# Altium schematic sheet MAIN.SchDoc(MAIN) (continued)

## Library data of the parts
C1 C5-C8 C10 C13 = C0603C105K8PACTU: CAP CER, 1UF, 10V, X5R, 10%, 0603; Manufacturer: KEMET; Dielectric Material: Ceramic; Case Code (Metric): 1608; Case/Package: 0603; Category: Ceramic Capacitors; Component Kind: Standard; ComponentLink1Description: https://datasheet.ciiva.com/11787/0900766b8116eb59-11787747.pdf?src-supplier=RSComponents; ComponentLink1URL: https://datasheet.ciiva.com/11787/0900766b8116eb59-11787747.pdf?src-supplier=RSComponents; ComponentLink2Description: https://datasheet.ciiva.com/6074/40889-6074647.pdf?src-supplier=Farnell; ComponentLink2URL: https://datasheet.ciiva.com/6074/40889-6074647.pdf?src-supplier=Farnell; ComponentLink3Description: https://datasheet.ciiva.com/28645/1831760-28645655.pdf?src-supplier=Element14; ComponentLink3URL: https://datasheet.ciiva.com/28645/1831760-28645655.pdf?src-supplier=Element14; ComponentLink4Description: https://datasheet.ciiva.com/26847/getdatasheetpartid-385480-26847548.pdf?src-supplier=Verical; ComponentLink4URL: https://datasheet.ciiva.com/26847/getdatasheetpartid-385480-26847548.pdf?src-supplier=Verical; ComponentLink5Description: https://datasheet.ciiva.com/7823/40889-7823998.pdf?src-supplier=Element14; ComponentLink5URL: https://datasheet.ciiva.com/7823/40889-7823998.pdf?src-supplier=Element14; ComponentLink6Description: https://datasheet.ciiva.com/7823/1929483-7823999.pdf?src-supplier=Element14; ComponentLink6URL: https://datasheet.ciiva.com/7823/1929483-7823999.pdf?src-supplier=Element14; Depth: 0.8mm; Dielectric: X5R; Height: 0.8mm; Lead Free: Lead Free; Length: 1.6mm; Library Name: Ceramic_Capacitor.SchLib; Manufacturer Part Number: C0603C105K8PACTU; Max Operating Temperature: 85°C; Min Operating Temperature: -55°C; Mount: Surface Mount; Number of Pins: 2; Package Quantity: 1; Packaging: Tape and Reel; Pricing 1: 1=0.10000, 10=0.05900, 50=0.03200, 100=0.02610, 500=0.01864, 1000=0.01464 (USD); Radiation Hardening: No; REACH SVHC: No SVHC; RoHS: Yes; Stock 1: 39312; Supplier 1: Digi-Key; Supplier Part Number 1: 399-3118-1-ND; Termination: SMD/SMT; Thickness: 0.035inch; Tolerance: 10%; Voltage: 10V; Voltage Rating: 10V; Voltage Rating (DC): 10V; Weight: 7.1E-05oz; Width: 0.031inch
  C1: Case Code (Imperial): 0603
C2 C17 = C0603C104K9RACTU: Cap Ceramic 0.1uF 6.3V X7R 10% Pad SMD 0603 125°C T/R; Manufacturer 1: KEMET; Capacitance: 100nF; Dissipation Factor: 5%; Case Code (Metric): 1608; Case/Package: 0603; Category: Ceramic Capacitors; Component Kind: Standard; ComponentLink1Description: https://datasheet.ciiva.com/13371/kem-c1002-x7r-smd-357932-13371879.pdf?src-supplier=Mouser; ComponentLink1URL: https://datasheet.ciiva.com/13371/kem-c1002-x7r-smd-357932-13371879.pdf?src-supplier=Mouser; Depth: 0.8mm; Dielectric: X7R; Dielectric Material: Ceramic; Height: 0.8mm; Length: 1.6mm; Library Name: Ceramic_Capacitor.SchLib; Manufacturer Part Number 1: C0603C104K9RACTU; Max Operating Temperature: 125°C; Min Operating Temperature: -55°C; Mount: Surface Mount; Package Quantity: 4000; Packaging: Tape and Reel; Pricing 1: 1=0,19000, 10=0,13800, 100=0,04500, 1000=0,03700, 4000=0,02700, 8000=0,02400 (USD); Radiation Hardening: No; RoHS: Yes; Stock 1: 22809; Supplier 1: Mouser; Supplier Part Number 1: 80-C0603C104K9R; Termination: SMD/SMT; Thickness: 0.034inch; Tolerance: 10%; Voltage Rating: 6.3V; Voltage Rating (DC): 6.3V; Width: 0.8mm
C3 C4 C15 C16 = C0603C103K5RACTU: Multilayer Ceramic Capacitor, C Series, 0.01 - F, - 10%, X7R, 50 V, 0603 [1608 Metric] ;RoHS Compliant: Yes; Manufacturer: KEMET; Dissipation Factor: 2.5%; Voltage: 50V; Case Code (Metric): 1608; Case/Package: 0603; Category: Ceramic Capacitors; Component Kind: Standard; ComponentLink1Description: https://datasheet.ciiva.com/7823/1732718-7823585.pdf?src-supplier=Element14; ComponentLink1URL: https://datasheet.ciiva.com/7823/1732718-7823585.pdf?src-supplier=Element14; ComponentLink2Description: https://datasheet.ciiva.com/5904/kem-c1002-x7r-smd-5904645.pdf?src-supplier=Farnell; ComponentLink2URL: https://datasheet.ciiva.com/5904/kem-c1002-x7r-smd-5904645.pdf?src-supplier=Farnell; ComponentLink3Description: https://datasheet.ciiva.com/18192/getdatasheetpartid-308803-18192662.pdf?src-supplier=Verical; ComponentLink3URL: https://datasheet.ciiva.com/18192/getdatasheetpartid-308803-18192662.pdf?src-supplier=Verical; ComponentLink4Description: https://datasheet.ciiva.com/39122/kem-c1002-x7r-smd-39122903.pdf?src-supplier=Onlinecomponents.com; ComponentLink4URL: https://datasheet.ciiva.com/39122/kem-c1002-x7r-smd-39122903.pdf?src-supplier=Onlinecomponents.com; ComponentLink5Description: https://datasheet.ciiva.com/7823/kem-c1002-x7r-smd-7823976.pdf?src-supplier=Element14; ComponentLink5URL: https://datasheet.ciiva.com/7823/kem-c1002-x7r-smd-7823976.pdf?src-supplier=Element14; ComponentLink6Description: https://datasheet.ciiva.com/7823/kem-c1002-x7r-smd-7823977.pdf?src-supplier=Element14; ComponentLink6URL: https://datasheet.ciiva.com/7823/kem-c1002-x7r-smd-7823977.pdf?src-supplier=Element14; ComponentLink7Description: https://datasheet.ciiva.com/7823/1918693-7823978.pdf?src-supplier=Element14; ComponentLink7URL: https://datasheet.ciiva.com/7823/1918693-7823978.pdf?src-supplier=Element14; ComponentLink8Description: https://datasheet.ciiva.com/14585/70095383-14585450.pdf?src-supplier=Allied+Electronics; ComponentLink8URL: https://datasheet.ciiva.com/14585/70095383-14585450.pdf?src-supplier=Allied+Electronics; ComponentLink9Description: https://datasheet.ciiva.com/18101/getdatasheetpartid-58468-18101898.pdf?src-supplier=Verical; ComponentLink9URL: https://datasheet.ciiva.com/18101/getdatasheetpartid-58468-18101898.pdf?src-supplier=Verical; ComponentLink10Description: https://datasheet.ciiva.com/5416/kem-c1002-x7r-smd-5416028.pdf?src-supplier=Mouser; ComponentLink10URL: https://datasheet.ciiva.com/5416/kem-c1002-x7r-smd-5416028.pdf?src-supplier=Mouser; ComponentLink11Description: https://datasheet.ciiva.com/28645/1851736-28645598.pdf?src-supplier=Element14; ComponentLink11URL: https://datasheet.ciiva.com/28645/1851736-28645598.pdf?src-supplier=Element14; Contact Plating: Tin,Matte; Depth: 0.8mm; Dielectric: X7R; Dielectric Material: Ceramic; Height: 0.8mm; Lead Pitch: 0.7mm; Length: 1.6mm; Library Name: Ceramic_Capacitor.SchLib; Manufacturer Part Number: C0603C103K5RACTU; Max Operating Temperature: 125°C; Min Operating Temperature: -55°C; Mount: Surface Mount,PCB; Number of Pins: 2; Package Quantity: 4000; Packaging: Tape and Reel; Pricing 1: 1=0.11000, 10=0.07700, 50=0.04220, 100=0.03440, 500=0.02456, 1000=0.01930 (USD); Radiation Hardening: No; REACH SVHC: No SVHC; RoHS: Yes; Stock 1: 2415850; Supplier 1: Digi-Key; Supplier Part Number 1: 399-1091-1-ND; Termination: SMD/SMT; Thickness: 0.034inch; Tolerance: 10%; Voltage Rating: 50V; Voltage Rating (DC): 50V; Width: 0.8mm
C9 C11 C14 = C0603C104K9RACTU: CAP CER, 0.1UF, 6.3V, X7R, 10%, 0603; Manufacturer 1: KEMET; Capacitance: 100nF; Dielectric Material: Ceramic; Dissipation Factor: 5%; Case Code (Metric): 1608; Case/Package: 0603; Category: Ceramic Capacitors; Component Kind: Standard; ComponentLink1Description: https://datasheet.ciiva.com/13371/kem-c1002-x7r-smd-357932-13371879.pdf?src-supplier=Mouser; ComponentLink1URL: https://datasheet.ciiva.com/13371/kem-c1002-x7r-smd-357932-13371879.pdf?src-supplier=Mouser; Depth: 0.8mm; Dielectric: X7R; Height: 0.8mm; Length: 1.6mm; Library Name: Ceramic_Capacitor.SchLib; Manufacturer Part Number 1: C0603C104K9RACTU; Max Operating Temperature: 125°C; Min Operating Temperature: -55°C; Mount: Surface Mount; Package Quantity: 4000; Packaging: Tape and Reel; Pricing 1: 1=0,19000, 10=0,13800, 100=0,04500, 1000=0,03700, 4000=0,02700, 8000=0,02400 (USD); Radiation Hardening: No; RoHS: Yes; Stock 1: 22809; Supplier 1: Mouser; Supplier Part Number 1: 80-C0603C104K9R; Termination: SMD/SMT; Thickness: 0.034inch; Tolerance: 10%; Voltage Rating: 6.3V; Voltage Rating (DC): 6.3V; Width: 0.8mm
  C9 C11: Case Code (Imperial): 0603
C12 = C0603C300G1HACTU: CAP CER, 22UF, 100V, X8R, 2%, 0603; Manufacturer: KEMET; Category: Ceramic Capacitors; Component Kind: Standard; Library Name: Ceramic_Capacitor.SchLib; Manufacturer Part Number: C0603C300G1HACTU; Pricing 1: 1=0.04000, 10=0.02900, 50=0.02900, 100=0.02500, 1000=0.01400, 10000=0.01200 (USD); RoHS: Yes; RoHS Compliant: Yes; Stock 1: 11458; Supplier 1: Mouser; Supplier Part Number 1: 80-C0603C300G1HACTU
C18 C19 = C0603C103K9RACTU: NM; Manufacturer 1: KEMET; Capacitance: 10nF; Dielectric: X7R; Dielectric Material: Ceramic; Dissipation Factor: 5%; Tolerance: 10%; Voltage Rating: 6.3V; Case Code (Imperial): 0603; Case Code (Metric): 1608; Case/Package: 0603; Category: Ceramic Capacitors; ComponentLink1Description: https://datasheet.ciiva.com/5421/kem-c1002-x7r-smd-357932-5421815.pdf?src-supplier=Mouser; ComponentLink1URL: https://datasheet.ciiva.com/5421/kem-c1002-x7r-smd-357932-5421815.pdf?src-supplier=Mouser; Depth: 0.8mm; Height: 0.8mm; Length: 1.6mm; Library Name: Ceramic_Capacitor.SchLib; Manufacturer Part Number 1: C0603C103K9RACTU; Max Operating Temperature: 125°C; Min Operating Temperature: -55°C; Mount: Surface Mount; Package Quantity: 1; Packaging: Tape and Reel; Pricing 1: 1=0,11000, 10=0,07600, 100=0,02400, 1000=0,02000, 4000=0,01600, 8000=0,01400 (USD); RoHS: Yes; Stock 1: 18988; Supplier 1: Mouser; Supplier Part Number 1: 80-C0603C103K9R; Termination: SMD/SMT; Thickness: 0.034inch; Voltage Rating (DC): 6.3V; Width: 0.031inch
D1 = PMEG4010CEGWX: DIODE SCHOTTKY 40V 1A 570mV SOD123; Manufacturer: Nexperia; Category: Schottky Diodes; Component Kind: Standard; ComponentLink1Description: http://www.farnell.com/datasheets/2290154.pdf?src-supplier=Farnell; ComponentLink1URL: http://www.farnell.com/datasheets/2290154.pdf?src-supplier=Farnell; ComponentLink2Description: https://assets.nexperia.com/documents/data-sheet/PMEG4010CEGW.pdf?src-supplier=Digi-Key; ComponentLink2URL: https://assets.nexperia.com/documents/data-sheet/PMEG4010CEGW.pdf?src-supplier=Digi-Key; ComponentLink3Description: http://www.farnell.com/datasheets/2290154.pdf?src-supplier=Element14; ComponentLink3URL: http://www.farnell.com/datasheets/2290154.pdf?src-supplier=Element14; ComponentLink4Description: http://www.farnell.com/datasheets/2290154.pdf?src-supplier=Newark; ComponentLink4URL: http://www.farnell.com/datasheets/2290154.pdf?src-supplier=Newark; Library Name: schottky.SchLib; Manufacturer Part Number: PMEG4010CEGWX; Pricing 1: 1=0.29000, 10=0.19400, 50=0.19400, 100=0.09100, 1000=0.05400, 10000=0.03500 (USD); RoHS: No; RoHS Compliant: No; Stock 1: 3187; Supplier 1: Mouser; Supplier Part Number 1: 841-PMEG4010CEGWX
D2 D3 = ESD5Z7.0T1G: TVS Diodes / ESD Suppressors SOD523 ESD DIODE; Manufacturer: ON Semiconductor; Breakdown Voltage: 7.5V; Capacitance: 65pF; Clamping Voltage: 22.7V; Diode Type: ZENER; Direction: Unidirectional; Element Configuration: Single; ESD Protection: Yes; Leakage Current: 10nA; Max Power Dissipation: 240W; Max Surge Current: 8.8A; Number of Channels: 1; Operating Supply Voltage: 7V; Peak Pulse Power: 200W; Power Line Protection: No; Test Current: 1mA; Case/Package: SOD-523-2; Category: ESD and Circuit Protection ICs; Clamping Voltage-Max: 22.7V; Component Kind: Standard; ComponentLink1Description: https://datasheet.ciiva.com/353/esd5z2-5t1-d-353760.pdf?src-supplier=Digi-Key; ComponentLink1URL: https://datasheet.ciiva.com/353/esd5z2-5t1-d-353760.pdf?src-supplier=Digi-Key; ComponentLink2Description: https://datasheet.ciiva.com/30478/0900766b8125092b-30478674.pdf?src-supplier=RSComponents; ComponentLink2URL: https://datasheet.ciiva.com/30478/0900766b8125092b-30478674.pdf?src-supplier=RSComponents; ComponentLink3Description: https://datasheet.ciiva.com/353/esd5z2-5t1-d-353761.pdf?src-supplier=Digi-Key; ComponentLink3URL: https://datasheet.ciiva.com/353/esd5z2-5t1-d-353761.pdf?src-supplier=Digi-Key; ComponentLink4Description: https://datasheet.ciiva.com/11195/esd5z2-5t1-d-11195333.pdf?src-supplier=Avnet; ComponentLink4URL: https://datasheet.ciiva.com/11195/esd5z2-5t1-d-11195333.pdf?src-supplier=Avnet; ComponentLink5Description: https://datasheet.ciiva.com/16437/esd5z2-5t1-d-16437829.pdf?src-supplier=Future+Electronics; ComponentLink5URL: https://datasheet.ciiva.com/16437/esd5z2-5t1-d-16437829.pdf?src-supplier=Future+Electronics; ComponentLink6Description: https://datasheet.ciiva.com/7973/esd5z2-5t1-d-7973851.pdf?src-supplier=Element14; ComponentLink6URL: https://datasheet.ciiva.com/7973/esd5z2-5t1-d-7973851.pdf?src-supplier=Element14; ComponentLink7Description: https://datasheet.ciiva.com/26817/getdatasheetpartid-120991-26817819.pdf?src-supplier=Verical; ComponentLink7URL: https://datasheet.ciiva.com/26817/getdatasheetpartid-120991-26817819.pdf?src-supplier=Verical; ComponentLink8Description: https://datasheet.ciiva.com/353/esd5z2-5t1-d-353759.pdf?src-supplier=Digi-Key; ComponentLink8URL: https://datasheet.ciiva.com/353/esd5z2-5t1-d-353759.pdf?src-supplier=Digi-Key; Contact Plating: Tin,Matte; Depth: 0.8mm; Halogen Free: Halogen Free; Height: 0.7mm; Lead Free: Lead Free; Length: 1.2mm; Library Name: ESD.SchLib; Manufacturer Part Number: ESD5Z7.0T1G; Max Breakdown Voltage: 7.5V; Max Operating Temperature: 150°C; Max Reverse Leakage Current: 10nA; Min Breakdown Voltage: 7.5V; Min Operating Temperature: -55°C; Number of Elements: 1; Number of Lines: 1; Number of Pins: 2; Number of Unidirectional Channels: 1; Package Quantity: 1; Packaging: Tape and Reel; Peak Pulse Current: 8.8A; Polarity: Unidirectional; Pricing 1: 1=0.17000, 10=0.11700, 50=0.11700, 100=0.05000, 1000=0.03500, 10000=0.02300 (USD); Radiation Hardening: No; REACH SVHC: No SVHC; Resistor Type: Zener; Reverse Breakdown Voltage: 7.5V; Reverse Standoff Voltage: 7V; RoHS: Yes; RoHS Compliant: Yes; Series: Automotive, AEC-Q101; Stock 1: 23017; Supplier 1: Mouser; Supplier Part Number 1: 863-ESD5Z7.0T1G; Termination: SMD/SMT; Width: 0.9mm; Working Voltage: 7V
D4 = KPG1-1608SURC-TT: Led, Red, 65MCD, 631NM, SMD, 1608; Manufacturer: Kingbright; Component Kind: Standard; ComponentLink1Description: http://www.farnell.com/datasheets/2163487.pdf?src-supplier=Farnell; ComponentLink1URL: http://www.farnell.com/datasheets/2163487.pdf?src-supplier=Farnell; Library Name: LED.SchLib; Manufacturer Part Number: KPG1-1608SURC-TT; Pricing 1: 25=0.16500 (USD); RoHS: No; Stock 1: 2675; Supplier 1: RSComponents; Supplier Part Number 1: 1254508
F1 = 0ZCK0075FF2E: BEL FUSE - 0ZCK0075FF2E - FUSE, RESETTABLE PTC, 6VDC, 0.75A, SMD; Hold Current: 750mA; Max Current Rating: 40A; Time to Trip: 0.2s; Trip Current: 1.5A; Case Code (Imperial): 0805; Case Code (Metric): 2012; Case/Package: 0805; Category: PTC Resettable Fuses; Component Kind: Standard; ComponentLink1Description: https://datasheet.ciiva.com/18550/0zck-18550716.pdf?src-supplier=Digi-Key; ComponentLink1URL: https://datasheet.ciiva.com/18550/0zck-18550716.pdf?src-supplier=Digi-Key; ComponentLink2Description: https://datasheet.ciiva.com/18550/0zck-18550717.pdf?src-supplier=Digi-Key; ComponentLink2URL: https://datasheet.ciiva.com/18550/0zck-18550717.pdf?src-supplier=Digi-Key; Lead Free: Lead Free; Manufacturer: Bel; Manufacturer Part Number: 0ZCK0075FF2E; Package Quantity: 1; Packaging: Tape and Reel; Pricing 1: 1=0.17000, 10=0.17000, 50=0.12300, 100=0.10900, 1000=0.08600, 10000=0.07300 (USD); RoHS: Yes; RoHS Compliant: Yes; Stock 1: 8730; Supplier 1: Mouser; Supplier Part Number 1: 530-0ZCK0075FF2E; Library Name: PPTC_Fuses.SchLib
F2 = Helical_868-915MHZ: 19x11mm Helical antenna. Requieres load matching  (impedance at 868MHz: 10-88j, VSWR 22); Library Name: antenna_pcb.SchLib
L1 = CVH252009-2R2M: 2.2uH, 1.3A , 20%, 1MHz; Manufacturer: Bourns; Core Material: Ferrite; DC Resistance (DCR): 80mR; Self Resonant Frequency: 40MHz; Shielding: Shielded; Case Code (Metric): 2520; Case/Package: 1008; Category: Fixed Inductors; Component Kind: Standard; ComponentLink1Description: https://datasheet.ciiva.com/6703/cvh25200920series-6703456.pdf?src-supplier=Digi-Key; ComponentLink1URL: https://datasheet.ciiva.com/6703/cvh25200920series-6703456.pdf?src-supplier=Digi-Key; ComponentLink2Description: https://datasheet.ciiva.com/3079/cvh-3079746.pdf?src-supplier=Avnet; ComponentLink2URL: https://datasheet.ciiva.com/3079/cvh-3079746.pdf?src-supplier=Avnet; ComponentLink3Description: https://datasheet.ciiva.com/7849/1610598-7849317.pdf?src-supplier=Element14; ComponentLink3URL: https://datasheet.ciiva.com/7849/1610598-7849317.pdf?src-supplier=Element14; ComponentLink4Description: https://datasheet.ciiva.com/22874/70301233-22874395.pdf?src-supplier=Allied+Electronics; ComponentLink4URL: https://datasheet.ciiva.com/22874/70301233-22874395.pdf?src-supplier=Allied+Electronics; ComponentLink5Description: https://datasheet.ciiva.com/6703/cvh252009series-6703455.pdf?src-supplier=Digi-Key; ComponentLink5URL: https://datasheet.ciiva.com/6703/cvh252009series-6703455.pdf?src-supplier=Digi-Key; Contact Plating: Tin; Current Rating: 1.3A; DC Current: 1.3A; Depth: 2.2mm; Height - Seated (Max): 0.039inch; Inductance Tolerance: 20%; Length: 0.098inch; Library Name: Fixed_inductors.SchLib; Manufacturer Part Number: CVH252009-2R2M; Max DC Current: 1.3A; Max Operating Temperature: 125°C; Military Standard: Not; Min Operating Temperature: -55°C; Mount: Surface Mount; Package Quantity: 3000; Packaging: Tape and Reel; Pricing 1: 1=0.23000, 10=0.18800, 50=0.16900, 100=0.15800, 1000=0.11100, 10000=0.08000 (USD); REACH SVHC: No SVHC; RoHS: Yes; RoHS Compliant: Yes; Series: CVH252009; Stock 1: 41990; Supplier 1: Mouser; Supplier Part Number 1: 652-CVH252009-2R2M; Termination: SMD/SMT; Test Frequency: 1MHz; Tolerance: 20%; Width: 0.079inch
L2 = 2506031217Z0: NM; Brand: Fair-Rite; Impedance: 120 Ohms; Maximum DC Current: 450 mA; Maximum DC Resistance: 300 mOhms; Test Frequency: 100 MHz; Tolerance: 25 %; Type: Multilayer Ferrite Chip Bead; Capacitance: -; Category: Ferrite Beads; ComponentLink1Description: https://www.mouser.com/datasheet/2/150/2506031217Z0-471794.pdf; ComponentLink1URL: https://www.mouser.com/datasheet/2/150/2506031217Z0-471794.pdf; Height: 0.8 mm; Length: 1.6 mm; Library Name: Ferrite.SchLib; Manufacturer: Fair-Rite; Manufacturer Part Number: 2506031217Z0; Maximum Operating Temperature: + 125 C; Minimum Operating Temperature: - 55 C; Minimum Order Quantity: 1; Operating Temperature Range: - 55 C to + 125 C; Package / Case: 0603 (1608 metric); Packaging: Cut Tape; Pricing 1: 1=0.032, 2500=0.027, 4000=0.025, 8000=0.024, 24000=0.022, 48000=0.021, 100000=0.018, 400000=0.016 (EUR); Product: Ferrite Chip Beads; Product Type: Ferrite Beads; Reeling: True; RoHS: RoHS Compliant; RoHS - Mouser: Y; Standard Pack Qty: 4000; Stock 1: 9619; Subcategory: Ferrites; Supplier 1: Mouser; Supplier Part Number 1: 623-2506031217Z0; Termination: -; Termination Style: SMD/SMT; Width: 0.8 mm
logo1 = Robolabo: Library Name: logo.SchLib
P1 P4 = CONN-2X15-1.27-MALE-RECT: Description: CONN-2X15-1.27-MALE-RECT; Library Name: pin.SchLib
P2 = S2B-PH-SM4-TB: CONN MALE RECT 2 POS 2mm; Component Kind: Standard; Library Name: PCB_CONNECTOR.SchLib
P3 = CONN-1X2-2.54-PCB: Library Name: pin.SchLib
P5 = CONN-2X5-1.27-MALE-RECT: Description: CONN-2X5-1.27-MALE-RECT; Library Name: pin.SchLib
P6 = 73412-0110: MOLEX   73412-0110   RF COAXIAL, MICROCOAXIAL, JACK, 50OHM; Body Material: Polymer,Liquid Crystal Polymer; Body Plating: Gold; Frequency: 6GHz; Gender: Female; Impedance: 50R; Insulation Resistance: 500MR; Mating Cycles: 30; Polarity: Standard; Voltage Rating: 60V; Category: RF / Coaxial Connectors; Component Kind: Standard; ComponentLink1Description: https://datasheet.ciiva.com/30219/250360734120110-rf-coax-nectors-30219809.pdf?src-supplier=Onlinecomponents.com; ComponentLink1URL: https://datasheet.ciiva.com/30219/250360734120110-rf-coax-nectors-30219809.pdf?src-supplier=Onlinecomponents.com; ComponentLink2Description: https://datasheet.ciiva.com/16653/0734120110-rf-coax-connectors-16653186.pdf?src-supplier=Avnet+Europe; ComponentLink2URL: https://datasheet.ciiva.com/16653/0734120110-rf-coax-connectors-16653186.pdf?src-supplier=Avnet+Europe; ComponentLink3Description: https://datasheet.ciiva.com/11762/0900766b80dfdeb4-11762356.pdf?src-supplier=RSComponents; ComponentLink3URL: https://datasheet.ciiva.com/11762/0900766b80dfdeb4-11762356.pdf?src-supplier=RSComponents; ComponentLink4Description: https://datasheet.ciiva.com/22773/1841262-22773140.pdf?src-supplier=Farnell; ComponentLink4URL: https://datasheet.ciiva.com/22773/1841262-22773140.pdf?src-supplier=Farnell; ComponentLink5Description: https://datasheet.ciiva.com/4600/0734120110-rf-coax-connectors-173239-4600647.pdf?src-supplier=Mouser; ComponentLink5URL: https://datasheet.ciiva.com/4600/0734120110-rf-coax-connectors-173239-4600647.pdf?src-supplier=Mouser; ComponentLink6Description: https://datasheet.ciiva.com/16081/1387750734120110-rf-coax-nectors-16081145.pdf?src-supplier=Onlinecomponents.com; ComponentLink6URL: https://datasheet.ciiva.com/16081/1387750734120110-rf-coax-nectors-16081145.pdf?src-supplier=Onlinecomponents.com; ComponentLink7Description: https://datasheet.ciiva.com/46384/1808577-46384459.pdf?src-supplier=Element14; ComponentLink7URL: https://datasheet.ciiva.com/46384/1808577-46384459.pdf?src-supplier=Element14; ComponentLink8Description: https://datasheet.ciiva.com/9419/0734120110-rf-coax-connectors-9419357.pdf?src-supplier=Avnet; ComponentLink8URL: https://datasheet.ciiva.com/9419/0734120110-rf-coax-connectors-9419357.pdf?src-supplier=Avnet; Connector Type: Coaxial; Contact Material: Bronze,Brass; Contact Plating: Gold; Halogen Free: Low Halogen; Lead Free: Lead Free; Library Name: RF_Connectors.SchLib; Manufacturer: Molex; Manufacturer Part Number: 73412-0110; Material: Bronze; Max Frequency: 6GHz; Max Operating Temperature: 90°C; Min Operating Temperature: -40°C; Mount: Surface Mount,Through Hole,PCB; Orientation: Straight; Package Quantity: 5000; Packaging: Tape and Reel; Pricing 1: 1=0.77000, 10=0.72200, 25=0.64960, 50=0.57740, 100=0.55330, 250=0.50520, 500=0.48114, 1000=0.39694, 2500=0.36086 (USD); Radiation Hardening: No; REACH SVHC: Unknown; RoHS: Yes; Stock 1: 98770; Supplier 1: Digi-Key; Supplier Part Number 1: WM5587CT-ND; Termination: Solder; Voltage Rating (AC): 60V
Q1 = SI2369DS-T1-GE3: VISHAY - SI2369DS-T1-GE3 - MOSFET, P-CH, -30V, -7.6A, 150DEG C; Manufacturer: Vishay Siliconix; Drain to Source Breakdown Voltage: 30V; Drain to Source Resistance: 29mR; Gate to Source Voltage (Vgs): 20V; Input Capacitance: 1.295nF; Max Power Dissipation: 2.5W; Number of Channels: 1; Case/Package: TO-236-3; Category: MOSFETs; Component Kind: Standard; ComponentLink1Description: https://datasheet.ciiva.com/29747/si2369d-29747874.pdf?src-supplier=Digi-Key; ComponentLink1URL: https://datasheet.ciiva.com/29747/si2369d-29747874.pdf?src-supplier=Digi-Key; ComponentLink2Description: https://datasheet.ciiva.com/29747/si2369d-29747879.pdf?src-supplier=Digi-Key; ComponentLink2URL: https://datasheet.ciiva.com/29747/si2369d-29747879.pdf?src-supplier=Digi-Key; Contact Plating: Tin,Matte; Continuous Drain Current (ID): -7.6A; Drain to Source Voltage (Vdss): 30V; Library Name: MOSFET.SchLib; Manufacturer Part Number: SI2369DS-T1-GE3; Max Operating Temperature: 150°C; Min Operating Temperature: -55°C; Mount: Surface Mount; Number of Pins: 3; Package Quantity: 3000; Packaging: Tape and Reel; Pricing 1: 1=0.36000, 10=0.32600, 100=0.24200, 500=0.19900, 1000=0.15300, 3000=0.14000 (USD); Radiation Hardening: No; Rds On Max: 29mR; REACH SVHC: No SVHC; RoHS: Yes; Stock 1: 37245; Supplier 1: Mouser; Supplier Part Number 1: 78-SI2369DS-T1-GE3
R1 R2 R5 = CRCW0603100KFKEAHP: RES SMD 100K OHM 1% 1/10W 0603; Manufacturer: Vishay; Max Power Dissipation: 250mW; Resistance: 100kR; Temperature Coefficient: 100ppm/°C; Voltage Rating: 75V; Case Code (Metric): 1608; Case/Package: 0603; Category: Chip SMD Resistors; Component Kind: Standard; ComponentLink1Description: https://datasheet.ciiva.com/3256/crcwhpe3-3256918.pdf?src-supplier=Avnet; ComponentLink1URL: https://datasheet.ciiva.com/3256/crcwhpe3-3256918.pdf?src-supplier=Avnet; ComponentLink2Description: https://datasheet.ciiva.com/2472/crcwhpe3-2472535.pdf?src-supplier=Digi-Key; ComponentLink2URL: https://datasheet.ciiva.com/2472/crcwhpe3-2472535.pdf?src-supplier=Digi-Key; ComponentLink3Description: https://datasheet.ciiva.com/2472/crcwhpe3-2472536.pdf?src-supplier=Digi-Key; ComponentLink3URL: https://datasheet.ciiva.com/2472/crcwhpe3-2472536.pdf?src-supplier=Digi-Key; ComponentLink4Description: https://datasheet.ciiva.com/16211/crcwhpe3-16211869.pdf?src-supplier=Future+Electronics; ComponentLink4URL: https://datasheet.ciiva.com/16211/crcwhpe3-16211869.pdf?src-supplier=Future+Electronics; ComponentLink5Description: https://datasheet.ciiva.com/7777/424479-7777270.pdf?src-supplier=Element14; ComponentLink5URL: https://datasheet.ciiva.com/7777/424479-7777270.pdf?src-supplier=Element14; ComponentLink6Description: https://datasheet.ciiva.com/7777/424479-7777271.pdf?src-supplier=Element14; ComponentLink6URL: https://datasheet.ciiva.com/7777/424479-7777271.pdf?src-supplier=Element14; ComponentLink7Description: https://datasheet.ciiva.com/2472/crcwhpe3-2472537.pdf?src-supplier=Digi-Key; ComponentLink7URL: https://datasheet.ciiva.com/2472/crcwhpe3-2472537.pdf?src-supplier=Digi-Key; Composition: Thick Film; Contact Plating: Tin; Depth: 0.85mm; Features: Automotive AEC-Q200; Height: 0.45mm; Lead Free: Lead Free; Length: 1.6mm; Library Name: Resistor.SchLib; Manufacturer Part Number: CRCW0603100KFKEAHP; Max Operating Temperature: 155°C; Military Standard: Not; Min Operating Temperature: -55°C; Number of Terminations: 2; Package Quantity: 10; Packaging: Tape and Reel; Power Rating: 250mW; Pricing 1: 1=0.16000, 10=0.13600, 100=0.05330, 1000=0.02232, 2500=0.02046 (USD); Radiation Hardening: No; REACH SVHC: Unknown; RoHS: Yes; Stock 1: 8564; Supplier 1: Digi-Key; Supplier Part Number 1: 541-100KSCT-ND; Termination: SMD/SMT; Tolerance: 1%; Voltage Rating (DC): 75V; Weight: 7.1E-05oz; Width: 0.8mm
  R2 R5: Case Code (Imperial): 0603
R3 R8 R10 = CRCW0603-0000Z0EA: RES SMD 0 OHM 0603; Manufacturer 1: Vishay; Current Rating: 2A; Max Power Dissipation: 100mW; Resistance: 0mR; Temperature Coefficient: 100ppm/°C; Tolerance: 5%; Voltage Rating: 75V; Case Code (Metric): 1608; Case/Package: 0603; Component Kind: Standard; ComponentLink1Description: https://datasheet.ciiva.com/2461/dcrcwe3-2461968.pdf?src-supplier=Digi-Key; ComponentLink1URL: https://datasheet.ciiva.com/2461/dcrcwe3-2461968.pdf?src-supplier=Digi-Key; ComponentLink2Description: https://datasheet.ciiva.com/2461/dcrcwe3-2461969.pdf?src-supplier=Digi-Key; ComponentLink2URL: https://datasheet.ciiva.com/2461/dcrcwe3-2461969.pdf?src-supplier=Digi-Key; ComponentLink3Description: https://datasheet.ciiva.com/2461/dcrcwe3-2461970.pdf?src-supplier=Digi-Key; ComponentLink3URL: https://datasheet.ciiva.com/2461/dcrcwe3-2461970.pdf?src-supplier=Digi-Key; ComponentLink4Description: https://datasheet.ciiva.com/8340/dcrcwe3-8340197.pdf?src-supplier=Avnet; ComponentLink4URL: https://datasheet.ciiva.com/8340/dcrcwe3-8340197.pdf?src-supplier=Avnet; ComponentLink5Description: https://datasheet.ciiva.com/16941/dcrcw-16941302.pdf?src-supplier=Avnet+Europe; ComponentLink5URL: https://datasheet.ciiva.com/16941/dcrcw-16941302.pdf?src-supplier=Avnet+Europe; ComponentLink6Description: https://datasheet.ciiva.com/16199/dcrcwe3-16199063.pdf?src-supplier=Future+Electronics; ComponentLink6URL: https://datasheet.ciiva.com/16199/dcrcwe3-16199063.pdf?src-supplier=Future+Electronics; ComponentLink7Description: https://datasheet.ciiva.com/16725/70201119-16725175.pdf?src-supplier=Allied+Electronics; ComponentLink7URL: https://datasheet.ciiva.com/16725/70201119-16725175.pdf?src-supplier=Allied+Electronics; ComponentLink8Description: https://datasheet.ciiva.com/26316/fld-crcw-e3-data-en-26316615.pdf?src-supplier=Distrelec; ComponentLink8URL: https://datasheet.ciiva.com/26316/fld-crcw-e3-data-en-26316615.pdf?src-supplier=Distrelec; ComponentLink9Description: https://datasheet.ciiva.com/5544/dcrcwe3-109170-5544344.pdf?src-supplier=Mouser; ComponentLink9URL: https://datasheet.ciiva.com/5544/dcrcwe3-109170-5544344.pdf?src-supplier=Mouser; ComponentLink10Description: https://datasheet.ciiva.com/7841/1720486-7841816.pdf?src-supplier=Element14; ComponentLink10URL: https://datasheet.ciiva.com/7841/1720486-7841816.pdf?src-supplier=Element14; ComponentLink11Description: https://datasheet.ciiva.com/7841/1720486-7841817.pdf?src-supplier=Element14; ComponentLink11URL: https://datasheet.ciiva.com/7841/1720486-7841817.pdf?src-supplier=Element14; ComponentLink12Description: https://datasheet.ciiva.com/7841/125364-7841818.pdf?src-supplier=Element14; ComponentLink12URL: https://datasheet.ciiva.com/7841/125364-7841818.pdf?src-supplier=Element14; ComponentLink13Description: https://datasheet.ciiva.com/16721/70201119-16721450.pdf?src-supplier=Allied+Electronics; ComponentLink13URL: https://datasheet.ciiva.com/16721/70201119-16721450.pdf?src-supplier=Allied+Electronics; Composition: Thick Film; Contact Plating: Tin,Lead; Depth: 0.85mm; Features: Automotive AEC-Q200; Height: 0.02inch; Lead Free: Lead Free; Length: 1.55mm; Library Name: Resistor.SchLib; Manufacturer Part Number 1: CRCW0603-0000Z0EA; Max Operating Temperature: 155°C; Military Standard: Not; Min Operating Temperature: -55°C; Mount: Surface Mount; Number of Terminations: 2; Package Quantity: 1; Packaging: Tape and Reel; Power Rating: 100mW; Pricing 1: 1=0,100000, 10=0,017000, 100=0,012000, 500=0,007000, 1000=0,006000, 2500=0,005000, 5000=0,004000, 10000=0,003000 (USD); Radiation Hardening: No; REACH SVHC: Unknown; RoHS: Yes; Stock 1: 1187486; Supplier 1: Mouser; Supplier Part Number 1: 71-CRCW0603-0-E3; Termination: SMD/SMT; Voltage Rating (DC): 75V; Weight: 7.1E-05oz; Width: 0.033inch; Working Voltage: 75V
R4 = CRCW060310K0FKEA: RES SMD 10K OHM 1% 1/10W 0603; Manufacturer: Vishay; Max Power Dissipation: 100mW; Resistance: 10kR; Temperature Coefficient: 100ppm/°C; Voltage Rating: 75V; Case Code (Metric): 1608; Case/Package: 0603; Category: Chip SMD Resistors; Component Kind: Standard; ComponentLink1Description: https://datasheet.ciiva.com/11809/0900766b80d98afc-11809037.pdf?src-supplier=RSComponents; ComponentLink1URL: https://datasheet.ciiva.com/11809/0900766b80d98afc-11809037.pdf?src-supplier=RSComponents; ComponentLink2Description: https://datasheet.ciiva.com/16886/dcrcw-16886037.pdf?src-supplier=Avnet+Europe; ComponentLink2URL: https://datasheet.ciiva.com/16886/dcrcw-16886037.pdf?src-supplier=Avnet+Europe; ComponentLink3Description: https://datasheet.ciiva.com/26821/getdatasheetpartid-86831-26821109.pdf?src-supplier=Verical; ComponentLink3URL: https://datasheet.ciiva.com/26821/getdatasheetpartid-86831-26821109.pdf?src-supplier=Verical; ComponentLink4Description: https://datasheet.ciiva.com/16754/70201119-16754710.pdf?src-supplier=Allied+Electronics; ComponentLink4URL: https://datasheet.ciiva.com/16754/70201119-16754710.pdf?src-supplier=Allied+Electronics; ComponentLink5Description: https://datasheet.ciiva.com/16719/70201119-16719704.pdf?src-supplier=Allied+Electronics; ComponentLink5URL: https://datasheet.ciiva.com/16719/70201119-16719704.pdf?src-supplier=Allied+Electronics; ComponentLink6Description: https://datasheet.ciiva.com/2465/dcrcwe3-2465513.pdf?src-supplier=Digi-Key; ComponentLink6URL: https://datasheet.ciiva.com/2465/dcrcwe3-2465513.pdf?src-supplier=Digi-Key; ComponentLink7Description: https://datasheet.ciiva.com/5543/dcrcwe3-109170-5543001.pdf?src-supplier=Mouser; ComponentLink7URL: https://datasheet.ciiva.com/5543/dcrcwe3-109170-5543001.pdf?src-supplier=Mouser; ComponentLink8Description: https://datasheet.ciiva.com/2465/dcrcwe3-2465514.pdf?src-supplier=Digi-Key; ComponentLink8URL: https://datasheet.ciiva.com/2465/dcrcwe3-2465514.pdf?src-supplier=Digi-Key; ComponentLink9Description: https://datasheet.ciiva.com/46385/1697337-46385498.pdf?src-supplier=Element14; ComponentLink9URL: https://datasheet.ciiva.com/46385/1697337-46385498.pdf?src-supplier=Element14; ComponentLink10Description: https://datasheet.ciiva.com/2465/dcrcwe3-2465515.pdf?src-supplier=Digi-Key; ComponentLink10URL: https://datasheet.ciiva.com/2465/dcrcwe3-2465515.pdf?src-supplier=Digi-Key; ComponentLink11Description: https://datasheet.ciiva.com/3256/dcrcwe3-3256939.pdf?src-supplier=Avnet; ComponentLink11URL: https://datasheet.ciiva.com/3256/dcrcwe3-3256939.pdf?src-supplier=Avnet; ComponentLink12Description: https://datasheet.ciiva.com/3256/dcrcwe3-3256940.pdf?src-supplier=Avnet; ComponentLink12URL: https://datasheet.ciiva.com/3256/dcrcwe3-3256940.pdf?src-supplier=Avnet; ComponentLink13Description: https://datasheet.ciiva.com/16209/dcrcwe3-16209164.pdf?src-supplier=Future+Electronics; ComponentLink13URL: https://datasheet.ciiva.com/16209/dcrcwe3-16209164.pdf?src-supplier=Future+Electronics; ComponentLink14Description: https://datasheet.ciiva.com/26314/fld-crcw-e3-data-en-26314749.pdf?src-supplier=Distrelec; ComponentLink14URL: https://datasheet.ciiva.com/26314/fld-crcw-e3-data-en-26314749.pdf?src-supplier=Distrelec; Composition: Thick Film; Contact Plating: Tin,Lead; Depth: 0.85mm; Features: Automotive AEC-Q200; Height: 0.45mm; Lead Free: Lead Free; Length: 1.55mm; Library Name: Resistor.SchLib; Manufacturer Part Number: CRCW060310K0FKEA; Max Operating Temperature: 155°C; Military Standard: Not; Min Operating Temperature: -55°C; Number of Pins: 2; Number of Terminations: 2; Package Quantity: 10; Packaging: Tape and Reel; Power Rating: 100mW; Pricing 1: 1=0.10000, 10=0.03300, 100=0.01340, 1000=0.00602, 2500=0.00522 (USD); Radiation Hardening: No; REACH SVHC: Unknown; RoHS: Yes; Stock 1: 633553; Supplier 1: Digi-Key; Supplier Part Number 1: 541-10.0KHCT-ND; Termination: SMD/SMT; Voltage Rating (DC): 75V; Weight: 7.1E-05oz; Width: 0.033inch
R6 R7 = CRCW06034K70FKTA: RES SMD 4.7K OHM 1% 1/10W 0603; Manufacturer 1: Vishay Dale; Max Power Dissipation: 100mW; Resistance: 4.7kR; Temperature Coefficient: 100ppm/°C; Voltage Rating: 75V; Case Code (Metric): 1608; Case/Package: 0603; Category: Chip SMD Resistors; Component Kind: Standard; ComponentLink1Description: https://datasheet.ciiva.com/2565/dcrcw-2565426.pdf?src-supplier=Digi-Key; ComponentLink1URL: https://datasheet.ciiva.com/2565/dcrcw-2565426.pdf?src-supplier=Digi-Key; ComponentLink2Description: https://datasheet.ciiva.com/9071/dcrcw-9071351.pdf?src-supplier=Avnet; ComponentLink2URL: https://datasheet.ciiva.com/9071/dcrcw-9071351.pdf?src-supplier=Avnet; Composition: Thick Film; Contact Plating: Tin,Lead; Depth: 0.85mm; Features: Automotive AEC-Q200; Height: 0.02inch; Lead Free: Contains Lead; Length: 1.55mm; Library Name: Resistor.SchLib; Manufacturer Part Number 1: CRCW06034K70FKTA; Max Operating Temperature: 155°C; Military Standard: Not; Min Operating Temperature: -55°C; Number of Terminations: 2; Package Quantity: 5000; Packaging: Tape and Reel; Power Dissipation: 100mW; Power Rating: 100mW; Pricing 1: 1=0,14000, 10=0,12400, 100=0,04700, 1000=0,01800, 5000=0,01400, 10000=0,01300, 25000=0,01200, 50000=0,01100 (USD); Radiation Hardening: No; RoHS: Yes; Stock 1: 10790; Supplier 1: Mouser; Supplier Part Number 1: 71-CRCW0603-4.7K; Tolerance: 1%; Voltage Rating (DC): 75V; Weight: 7.1E-05oz; Width: 0.033inch
R9 = CRCW0603470RFKEAHP: RES SMD 470 OHM 1% 1/10W 0603; Manufacturer: Vishay; Max Power Dissipation: 250mW; Temperature Coefficient: 100ppm/°C; Voltage Rating: 75V; Case Code (Metric): 1608; Case/Package: 0603; Category: Chip SMD Resistors; Component Kind: Standard; ComponentLink1Description: https://datasheet.ciiva.com/8934/crcwhpe3-8934713.pdf?src-supplier=Avnet; ComponentLink1URL: https://datasheet.ciiva.com/8934/crcwhpe3-8934713.pdf?src-supplier=Avnet; ComponentLink2Description: https://datasheet.ciiva.com/16884/crcwhpe3-16884505.pdf?src-supplier=Avnet+Europe; ComponentLink2URL: https://datasheet.ciiva.com/16884/crcwhpe3-16884505.pdf?src-supplier=Avnet+Europe; ComponentLink3Description: https://datasheet.ciiva.com/7777/424479-7777323.pdf?src-supplier=Element14; ComponentLink3URL: https://datasheet.ciiva.com/7777/424479-7777323.pdf?src-supplier=Element14; ComponentLink4Description: https://datasheet.ciiva.com/7777/424479-7777324.pdf?src-supplier=Element14; ComponentLink4URL: https://datasheet.ciiva.com/7777/424479-7777324.pdf?src-supplier=Element14; Composition: Thick Film; Contact Plating: Tin; Depth: 0.85mm; Features: Automotive AEC-Q200; Height: 0.022inch; Lead Free: Lead Free; Length: 1.6mm; Library Name: Resistor.SchLib; Manufacturer Part Number: CRCW0603470RFKEAHP; Max Operating Temperature: 155°C; Military Standard: Not; Min Operating Temperature: -55°C; Mount: Surface Mount; Number of Terminations: 2; Package Quantity: 1; Packaging: Tape and Reel; Power Rating: 250mW; Pricing 1: 1=0.16000, 10=0.13600, 100=0.05330, 1000=0.02232, 2500=0.02046 (USD); Radiation Hardening: No; REACH SVHC: Unknown; RoHS: Yes; Stock 1: 42034; Supplier 1: Digi-Key; Supplier Part Number 1: 541-470SCT-ND; Termination: SMD/SMT; Tolerance: 1%; Voltage Rating (DC): 75V; Width: 0.033inch
S1 = KMR441NGLFS: Manufacturer: ITT C&K; Actuator Length: 1.1mm; Actuator Type: Standard; Current Rating: 50mA; Illumination Type: Non-Illuminated,Illuminated; Max Voltage Rating (DC): 32V; Mechanical Life: 100000Cycles; Operating Force: 4N; Power Rating: 1W; Switch Function: Off-Mom; Switch Travel: 0.35mm; Case/Package: SMD/SMT; Category: Tactile Switches; Component Kind: Standard; ComponentLink1Description: https://datasheet.ciiva.com/2900/kmr4-9aug12-2900281.pdf?src-supplier=Digi-Key; ComponentLink1URL: https://datasheet.ciiva.com/2900/kmr4-9aug12-2900281.pdf?src-supplier=Digi-Key; ComponentLink2Description: https://datasheet.ciiva.com/7986/1443639-7986953.pdf?src-supplier=Element14; ComponentLink2URL: https://datasheet.ciiva.com/7986/1443639-7986953.pdf?src-supplier=Element14; ComponentLink3Description: https://datasheet.ciiva.com/2900/kmr4-9aug12-2900282.pdf?src-supplier=Digi-Key; ComponentLink3URL: https://datasheet.ciiva.com/2900/kmr4-9aug12-2900282.pdf?src-supplier=Digi-Key; ComponentLink4Description: https://datasheet.ciiva.com/2900/kmr4-9aug12-2900283.pdf?src-supplier=Digi-Key; ComponentLink4URL: https://datasheet.ciiva.com/2900/kmr4-9aug12-2900283.pdf?src-supplier=Digi-Key; ComponentLink5Description: https://datasheet.ciiva.com/16696/kmr4-9aug12-16696492.pdf?src-supplier=Avnet+Europe; ComponentLink5URL: https://datasheet.ciiva.com/16696/kmr4-9aug12-16696492.pdf?src-supplier=Avnet+Europe; Contact Material: Silver; Contact Plating: Silver; Depth: 4.6mm; Description: SPST 4.6x2.8mm; Length: 2.8mm; Library Name: switch.SchLib; Manufacturer Part Number: KMR441NGLFS; Max Current Rating: 50mA; Max Operating Temperature: 85°C; Min Operating Temperature: -40°C; Mount: Surface Mount; Orientation: Straight; Package Quantity: 6000; Packaging: Tape and Reel; Pricing 1: 1=0.99000, 10=0.95100, 25=0.93300, 50=0.89700, 100=0.77400, 250=0.70600 (USD); Radiation Hardening: No; REACH SVHC: No SVHC; RoHS: Yes; Stock 1: 6000; Supplier 1: Mouser; Supplier Part Number 1: 611-KMR441NGLFS; Termination: Gull Wing; Throw Configuration: SPST; Voltage Rating (DC): 32V
SB1 = SB_3P: Solder Bridge smd; Library Name: solder_brige.SchLib
SB2-SB4 = SB_2P: Solder Bridge smd; Library Name: solder_brige.SchLib
screw1-screw3 = screw_M2: Component Kind: Standard; Library Name: screw.SchLib
TP1-TP15 = TP SMD: Library Name: test_point.SchLib
U1 = TPS22919DCKR: 5.5-V, 1.5-A, 90-mΩ load switch with adj. output discharge 6-SC70 -40 to 105; Manufacturer: Texas Instruments; Component Kind: Standard; Library Name: load_switch.SchLib; Manufacturer Part Number: TPS22919DCKR; Pricing 1: 1=0.39000, 10=0.29100, 25=0.26240, 100=0.14310, 250=0.13832, 500=0.12402, 1000=0.09540 (USD); RoHS: Yes; RoHS Compliant: Yes; Stock 1: 68498; Supplier 1: Digi-Key; Supplier Part Number 1: 296-TPS22919DCKRCT-ND
U2 = CMWX1ZZABZ-078: LORA MODULE; Manufacturer 1: Murata; Current - Receiving: 23mA; Current - Transmitting: 106mA; Frequency: 915MHz; Interface: I2C,SPI,USB,UART; Sensitivity: -133dBm; Case/Package: Module; Component Kind: Standard; ComponentLink1Description: https://wireless.murata.com/RFM/data/type_abz.pdf?src-supplier=Digi-Key; ComponentLink1URL: https://wireless.murata.com/RFM/data/type_abz.pdf?src-supplier=Digi-Key; Library Name: mcu.SchLib; Manufacturer Part Number 1: CMWX1ZZABZ-078; Max Operating Temperature: 85°C; Min Operating Temperature: -40°C; Mount: Surface Mount; Packaging: Cut Tape; Pricing 1: 1=16,54000, 10=13,78000, 50=13,78000, 100=12,60000, 200=11,75000, 500=11,54000 (USD); RoHS: No; Stock 1: 1484; Supplier 1: Mouser; Supplier Part Number 1: 81-CMWX1ZZABZ-078
U3 = RP605K333B-TR: Manufacturer: Ricoh; Component Kind: Standard; Library Name: Switching_VR.SchLib; Manufacturer Part Number: RP605K333B-TR; Pricing 1: 1=2.24000, 10=1.90000, 50=1.90000, 100=1.52000, 1000=1.11000, 10000=0.98800 (USD); RoHS: No; Stock 1: 4115; Supplier 1: Mouser; Supplier Part Number 1: 848-RP605K333B-TR
U4 = TPS3839K33DBZR: IC LP SUPERVISRY CIRCUIT SOT23-3; Manufacturer: Texas Instruments; Max Reset Threshold Voltage: 2.974V; Max Supply Current: 500nA; Max Supply Voltage: 6.5V; Min Reset Threshold Voltage: 2.857V; Min Supply Voltage: 900mV; Number of Monitored Inputs: 1; Output: TOTEM-POLE; Quiescent Current: 150nA; Reset: Active Low; Reset Timeout: 120ms; Threshold Voltage: 2.93V; Watchdog Timer: No; Case/Package: SOT-23; Category: Voltage Supervisors; Component Kind: Standard; ComponentLink1Description: https://datasheet.ciiva.com/15105/getdatasheetpartid-682590-15105743.pdf?src-supplier=Verical; ComponentLink1URL: https://datasheet.ciiva.com/15105/getdatasheetpartid-682590-15105743.pdf?src-supplier=Verical; ComponentLink2Description: https://datasheet.ciiva.com/6602/tps3839k33-6602670.pdf?src-supplier=Digi-Key; ComponentLink2URL: https://datasheet.ciiva.com/6602/tps3839k33-6602670.pdf?src-supplier=Digi-Key; ComponentLink3Description: https://datasheet.ciiva.com/6602/tps3839k33-6602669.pdf?src-supplier=Digi-Key; ComponentLink3URL: https://datasheet.ciiva.com/6602/tps3839k33-6602669.pdf?src-supplier=Digi-Key; ComponentLink4Description: https://datasheet.ciiva.com/6602/tps3839k33-6602671.pdf?src-supplier=Digi-Key; ComponentLink4URL: https://datasheet.ciiva.com/6602/tps3839k33-6602671.pdf?src-supplier=Digi-Key; Lead Free: Lead Free; Manufacturer Part Number: TPS3839K33DBZR; Max Operating Temperature: 85°C; Min Operating Temperature: -40°C; Mount: Surface Mount; Number of Pins: 3; Number of Voltages Monitored: 1; Package Quantity: 1; Packaging: Tape and Reel; Pricing 1: 1=0.70000, 10=0.57400, 50=0.57400, 100=0.43500, 1000=0.26900, 10000=0.25000 (USD); Radiation Hardening: No; REACH SVHC: No SVHC; RoHS: Yes; RoHS Compliant: Yes; Series: TPS3839K33; Stock 1: 776; Supplier 1: Mouser; Supplier Part Number 1: 595-TPS3839K33DBZR; Type: Simple Reset/Power-On Reset; Library Name: circuit_supervisor.SchLib
U5 = BME680: Pressure, Temperature and Humidity Sensor Digital Output 1.8V 8-Pin LGA; Manufacturer: Bosch Sensortec; Output Type: SPI,I2C; Category: Temperature and Humidity Sensors; Component Kind: Standard; ComponentLink1Description: https://ae-bst.resource.bosch.com/media/_tech/media/application_notes/BST-BME680-HS000-05.pdf?src-supplier=Digi-Key; ComponentLink1URL: https://ae-bst.resource.bosch.com/media/_tech/media/application_notes/BST-BME680-HS000-05.pdf?src-supplier=Digi-Key; ComponentLink2Description: https://ae-bst.resource.bosch.com/media/_tech/media/product_flyer/BST-BME680-FL000.pdf?src-supplier=Digi-Key; ComponentLink2URL: https://ae-bst.resource.bosch.com/media/_tech/media/product_flyer/BST-BME680-FL000.pdf?src-supplier=Digi-Key; Library Name: Temperature_Sensors.SchLib; Manufacturer Part Number: BME680; Max Operating Temperature: 85°C; Min Operating Temperature: -40°C; Pricing 1: 1=12.14000, 5=10.65000, 10=9.58500, 25=8.52000, 50=7.88100, 100=6.81600, 500=6.39000, 1000=5.96400 (USD); RoHS: No; Sensor Type: Temperature; Stock 1: 4127; Supplier 1: Digi-Key; Supplier Part Number 1: 828-1077-1-ND
